# Altium schematic sheet POWER_IN.SchDoc(POWER_IN)
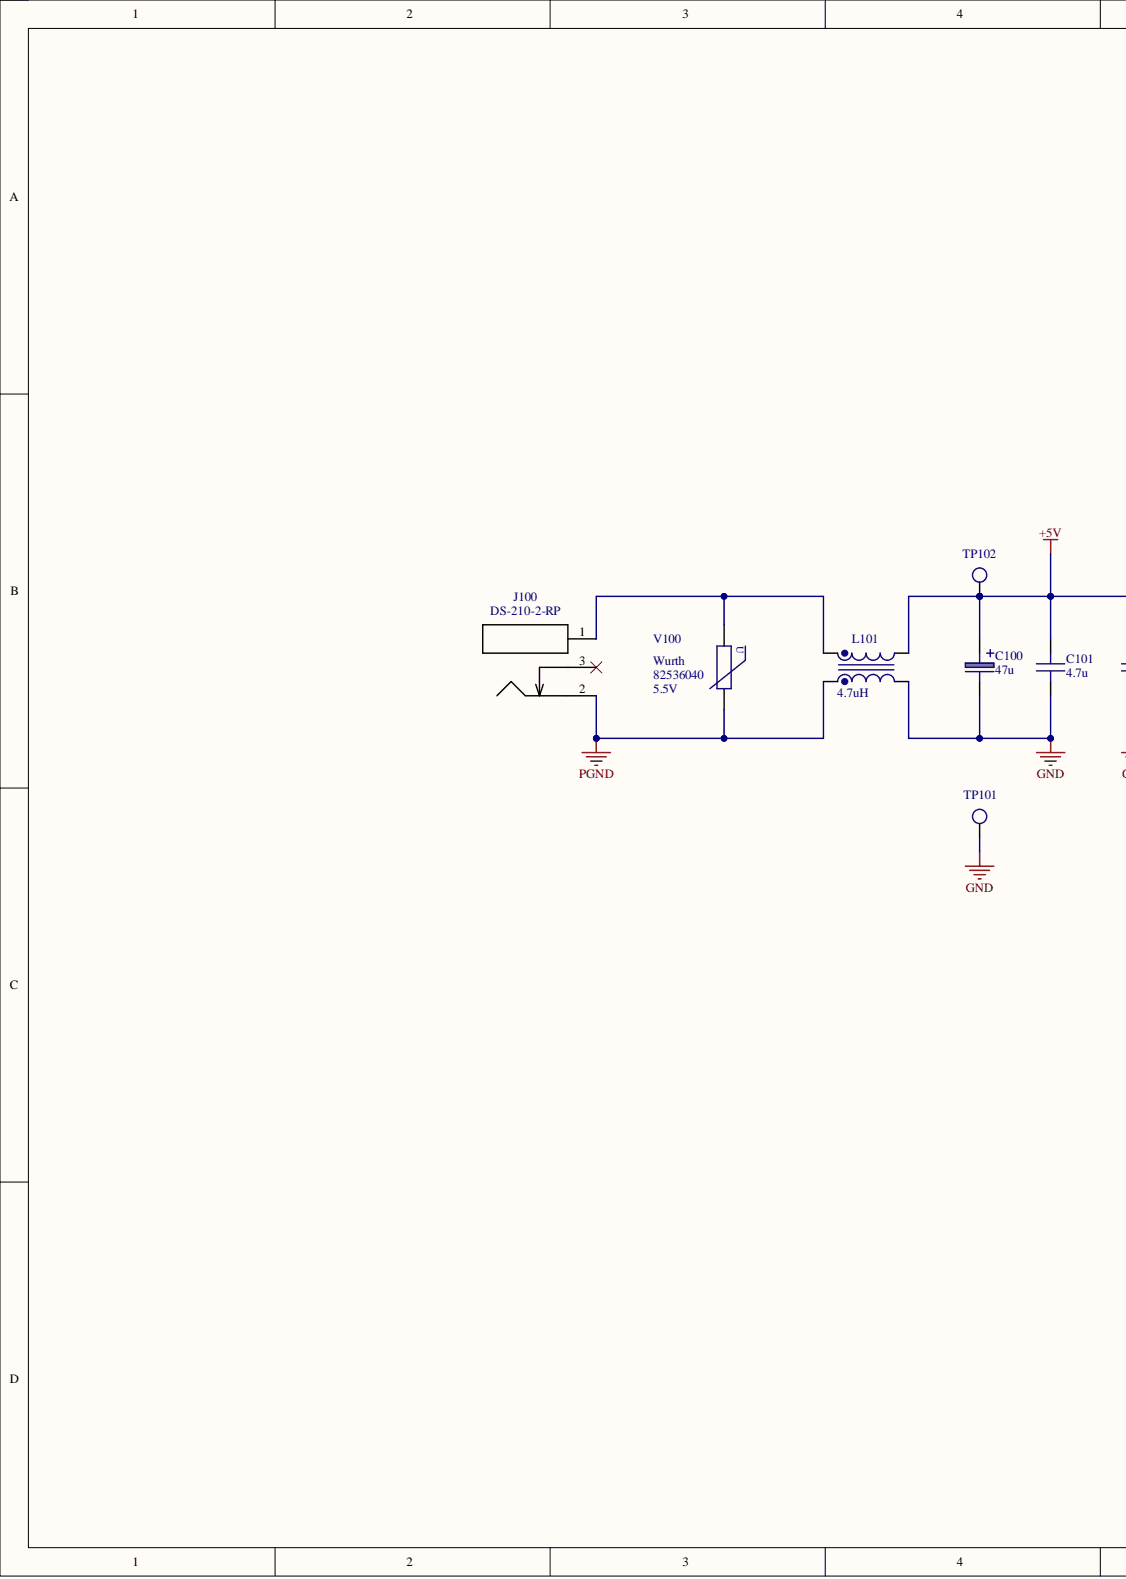
[diagram p0: POWER_IN.SchDoc, left]
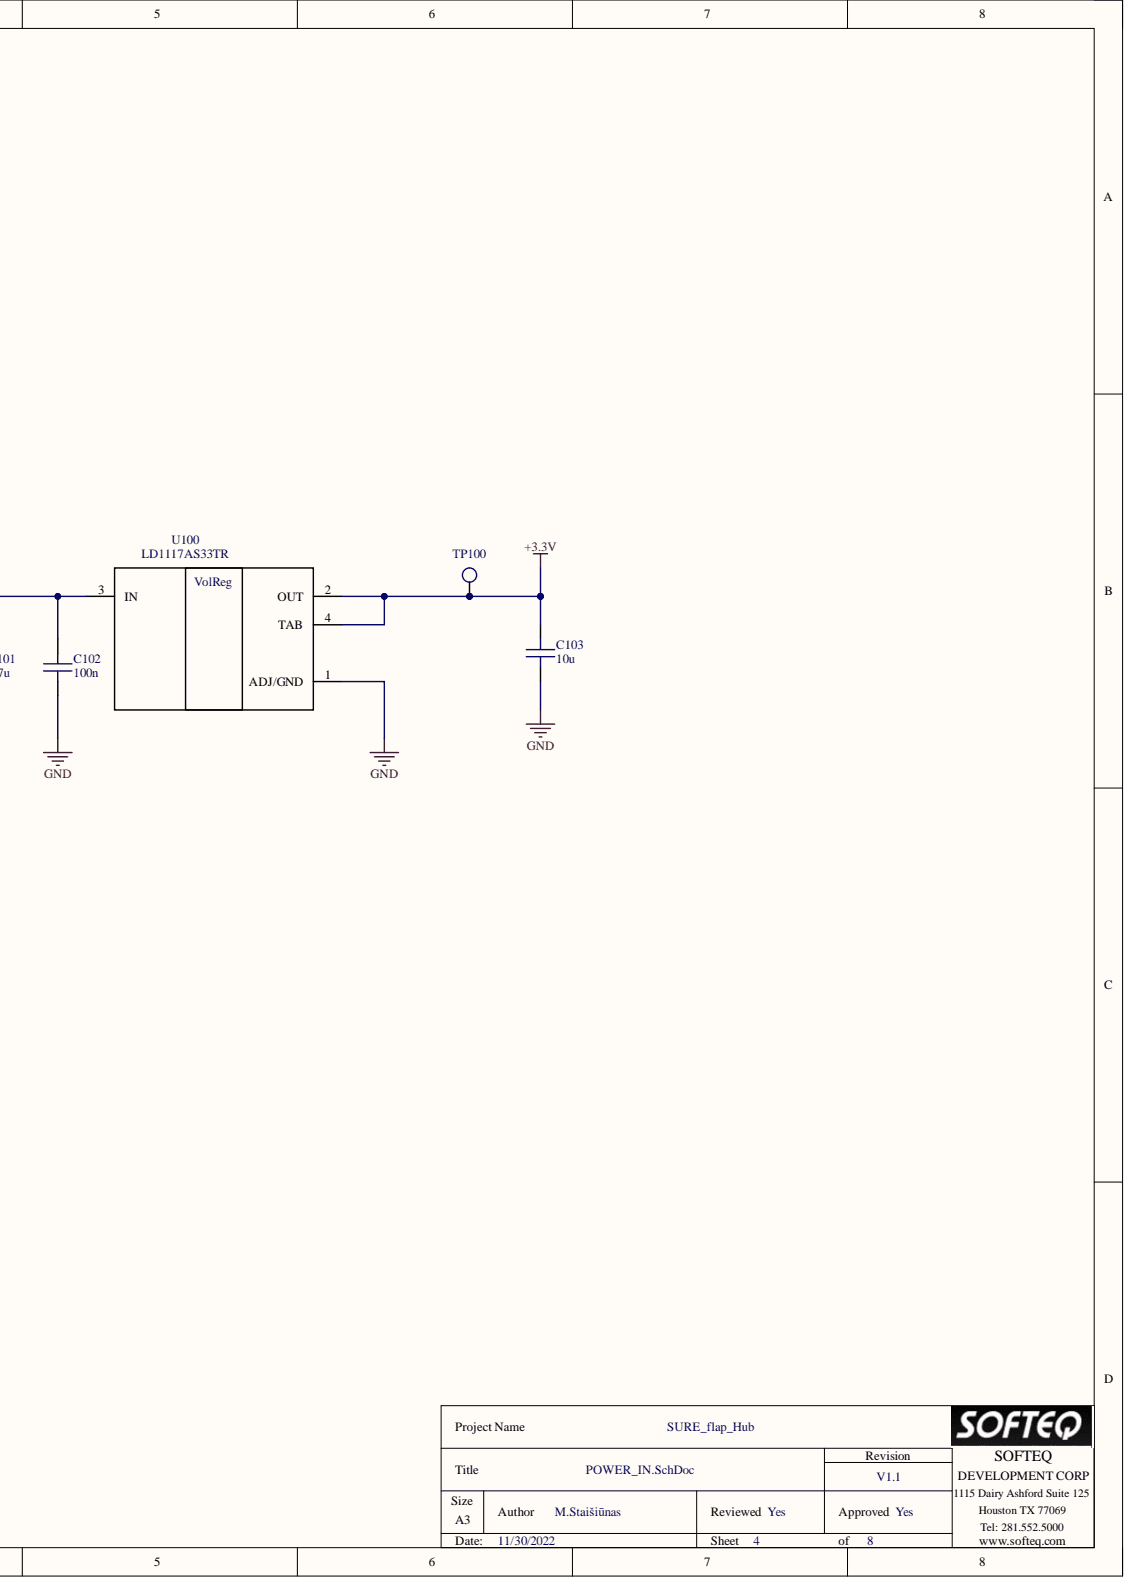
[diagram p1: POWER_IN.SchDoc, right]

## Parts
C100  value 47u  [NICHICON_UWF1C470MCL1GB]  (hidden comment: UWF1C470MCL1GB)
C101  value 4.7u  [C_0805]  (hidden comment: CL21A475KOFNNNE)
C102  value 100n  [C_0603]  (hidden comment: CC0603KRX7R9BB104)
C103  value 10u  [C_0805]  (hidden comment: CC0805KKX5R6BB106)
J100  DS-210-2-RP  [RP_DS-210-2-RP_ALT]
L101  value 4.7uH  [WE_744220]  (hidden comment: 744220)
TP100  SolderPoint_2.0  [SSP_2.0]  (value not drawn)  (no BOM)
TP101  SolderPoint_2.0  [SSP_2.0]  (value not drawn)  (no BOM)
TP102  SolderPoint_2.0  [SSP_2.0]  (value not drawn)  (no BOM)
U100  LD1117AS33TR  [SOT-223-4]
V100  82536040  Manufacturer: Wurth  [WE_0603]

## Nets
+3.3V: C103.2 TP100.1 U100.2(OUT) U100.4(TAB)
+5V: C100.1 C101.2 C102.2 L101.4 TP102.1 U100.3(IN)
GND: C100.2 C101.1 C102.1 C103.1 L101.3 TP101.1 U100.1(ADJ/GND)
PGND: J100.2 L101.2 V100.2
NetJ100_1: J100.1 L101.1 V100.1

Not connected: J100 3

## Library data of the parts
C100 = UWF1C470MCL1GB: Capacitor, Al, 47uF, 20%, 16V, -55/+105, SMD; Manufacturer: Nichicon; Database Table Name: Capacitors; Component Kind: Standard; ComponentHeight: 5.4mm; Library Name: Library.dblib; Mounted: Yes; PartNumber: UWF1C470MCL1GB; SMD: Yes; Author: DZ
C101 = CL21A475KOFNNNE: Capacitor, 4.7uF, 10%, 16V, X5R, -55/+85, 0805; Manufacturer: Samsung; Database Table Name: Capacitors; Component Kind: Standard; ComponentHeight: 1.35mm; Library Name: Library.dblib; Mounted: Yes; PartNumber: CL21A475KOFNNNE; SMD: Yes; Author: DZ
C102 = CC0603KRX7R9BB104: Capacitor, 100nF, 50V, X7R, 10%, -55/+125, 0603; Manufacturer: Yageo; Database Table Name: Capacitors; Component Kind: Standard; ComponentHeight: 0.8mm; Library Name: Library.dblib; Mounted: Yes; PartNumber: CC0603KRX7R9BB104; SMD: Yes; Author: KAI
C103 = CC0805KKX5R6BB106: Capacitor, 10uF, 10V, X5R, 10%, -55/+85, 0805; Manufacturer: Yageo; Database Table Name: Capacitors; Component Kind: Standard; ComponentHeight: 1.45mm; Library Name: Library.dblib; Mounted: Yes; PartNumber: CC0805KKX5R6BB106; SMD: Yes; Author: KAI
J100 = DS-210-2-RP: Conn, Power Jack, 2.1x5.5mm, 24VDC, 8A, RA, -25/+85, TH; Manufacturer: Rainbow Production; Database Table Name: Connectors; Component Kind: Standard; ComponentHeight: 11mm; Library Name: Library.dblib; Mounted: Yes; PartNumber: DS-210-2-RP; SMD: No; Author: DZ; HelpURL: Z:\Libs\Datasheets\Connectors\DS-210-RP\DS-210-2-RP.pdf
L101 = 744220: Choke, Common Mode, 2 Line, 4.7uH/100kHz, 500mA, -40/+125, 9.2x6.0x5.2mm, SMD; Manufacturer: Wurth; Database Table Name: Inductor_Transformer; Component Kind: Standard; ComponentHeight: 5.3mm; Library Name: Library.dblib; Mounted: Yes; PartNumber: 744220; Power: 500mA; SMD: Yes; Author: DZ
TP100-TP102 = SolderPoint_SMD_2.0: Solder Point, 2.0mm, SMD; Database Table Name: Connectors; ComponentHeight: 0mm; Library Name: Library.dblib; Mounted: Yes; PartNumber: SolderPoint_SMD_2.0; SMD: Yes; Author: KAI
U100 = LD1117AS33TR: IC, VolReg, LDO, 2.5-15V/3.3V, 1A, 0/+125,  SOT-223; Manufacturer: STMicroelectronics; Database Table Name: IC Power; Component Kind: Standard; ComponentHeight: 1.8mm; Library Name: Library.dblib; Mounted: Yes; PartNumber: LD1117AS33TR; SMD: Yes; Author: DZ; HelpURL: Z:\Libs\Datasheets\IC Power\LD1117AS33TR\LD1117AS33TR.pdf
V100 = 82536040: Varistor,5.5VDC, 30A, 200pF/1kHz, -40/+85, 0603; Database Table Name: Resistors; Component Kind: Standard; ComponentHeight: 0.9mm; Library Name: Library.dblib; Mounted: Yes; PartNumber: 82536040; SMD: Yes; Author: DZ
